# Altium schematic sheet Detection_Circuit_Sheet_02.SchDoc
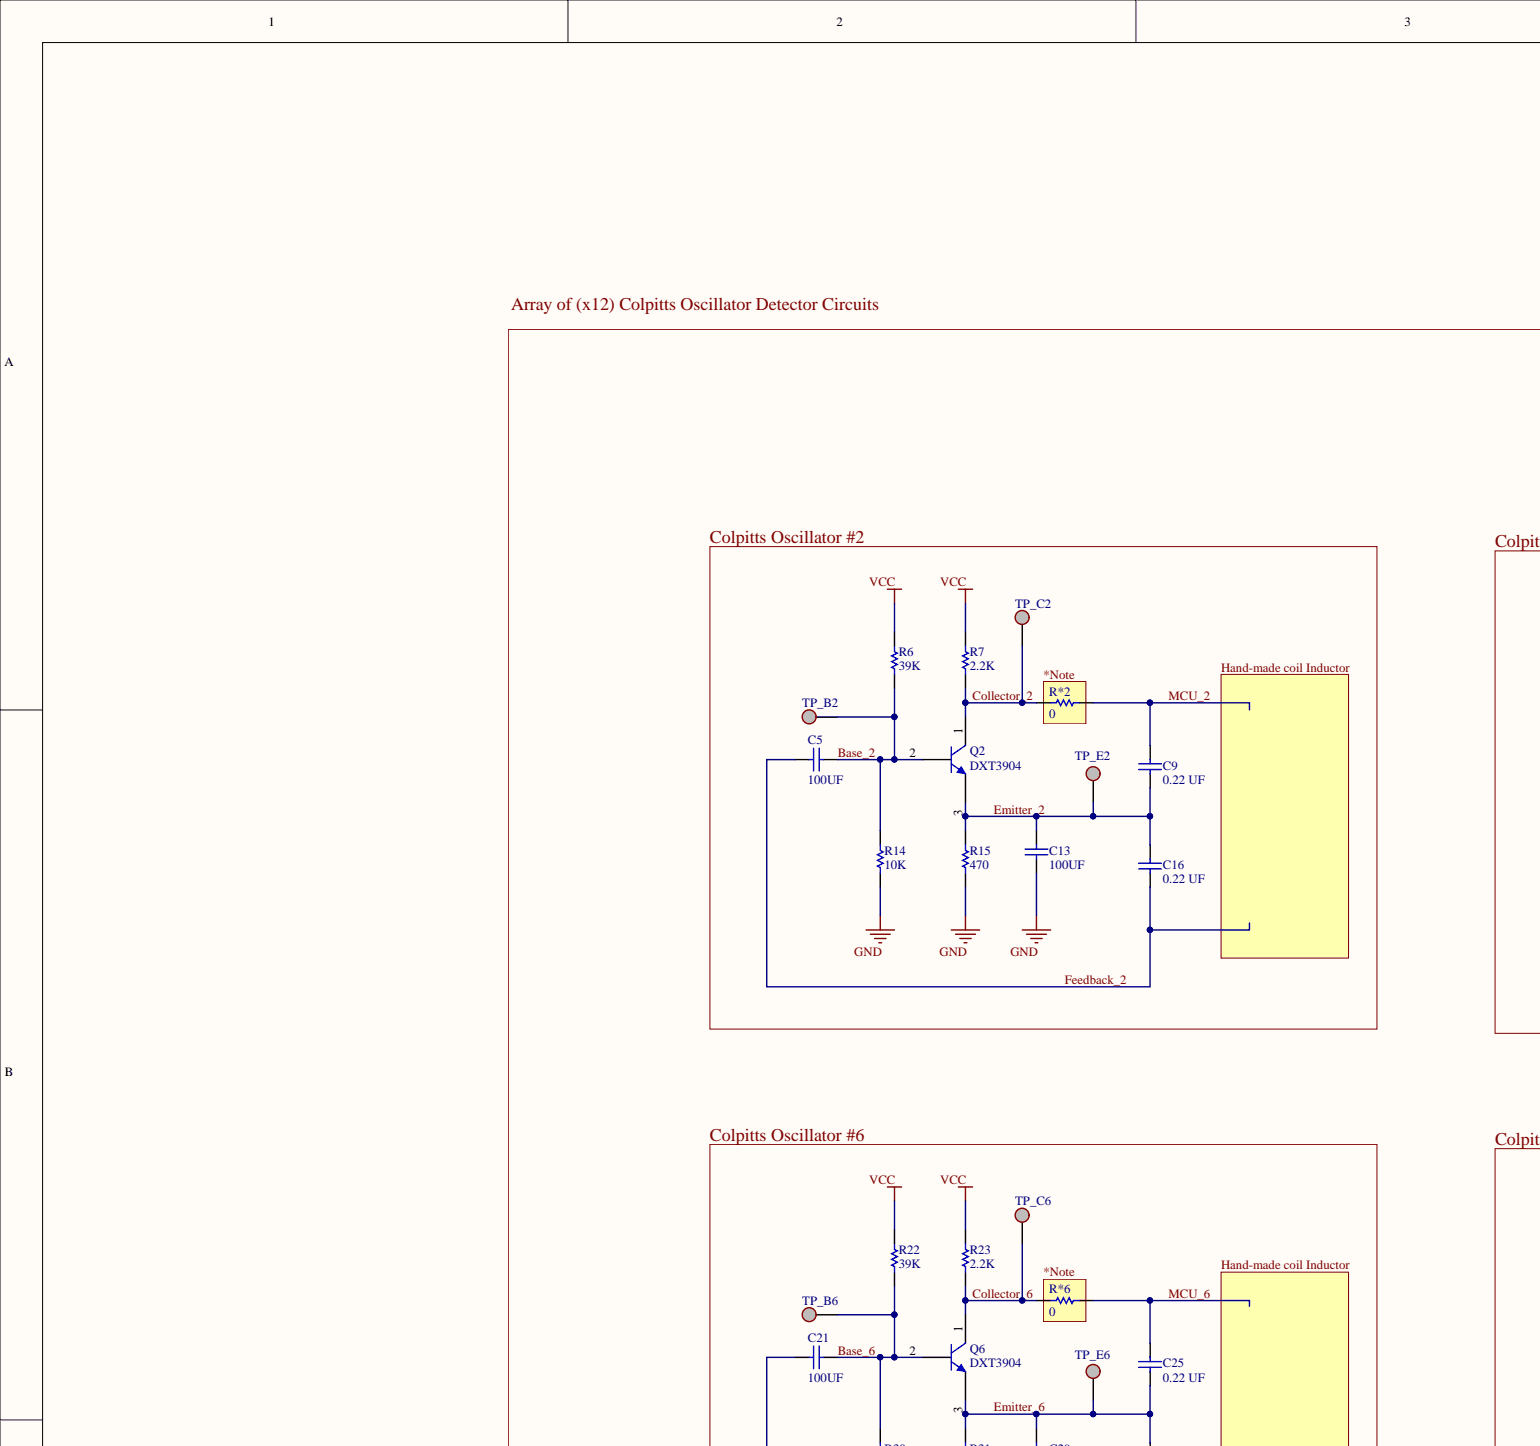
[diagram p0: Detection_Circuit_Sheet_02.SchDoc, top left]
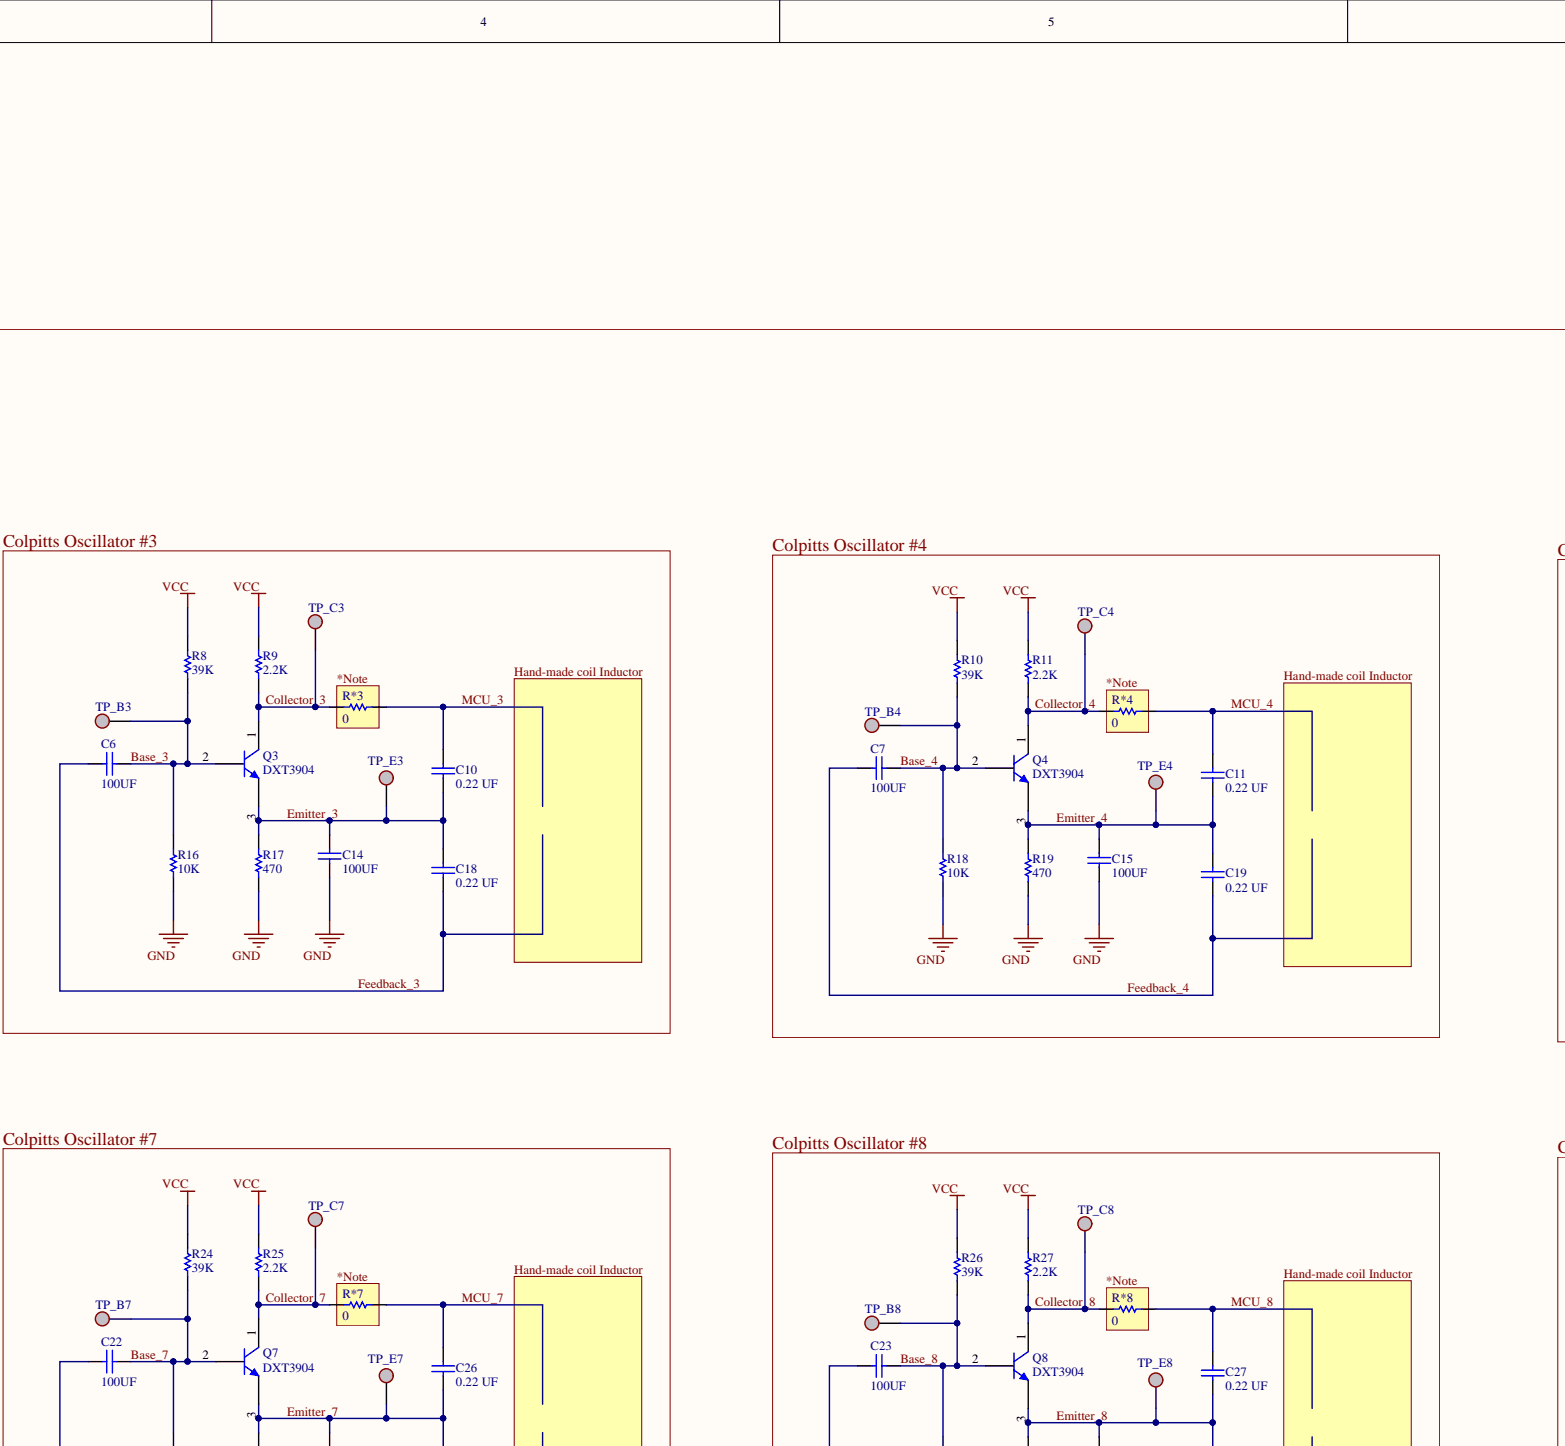
[diagram p1: Detection_Circuit_Sheet_02.SchDoc, top centre]
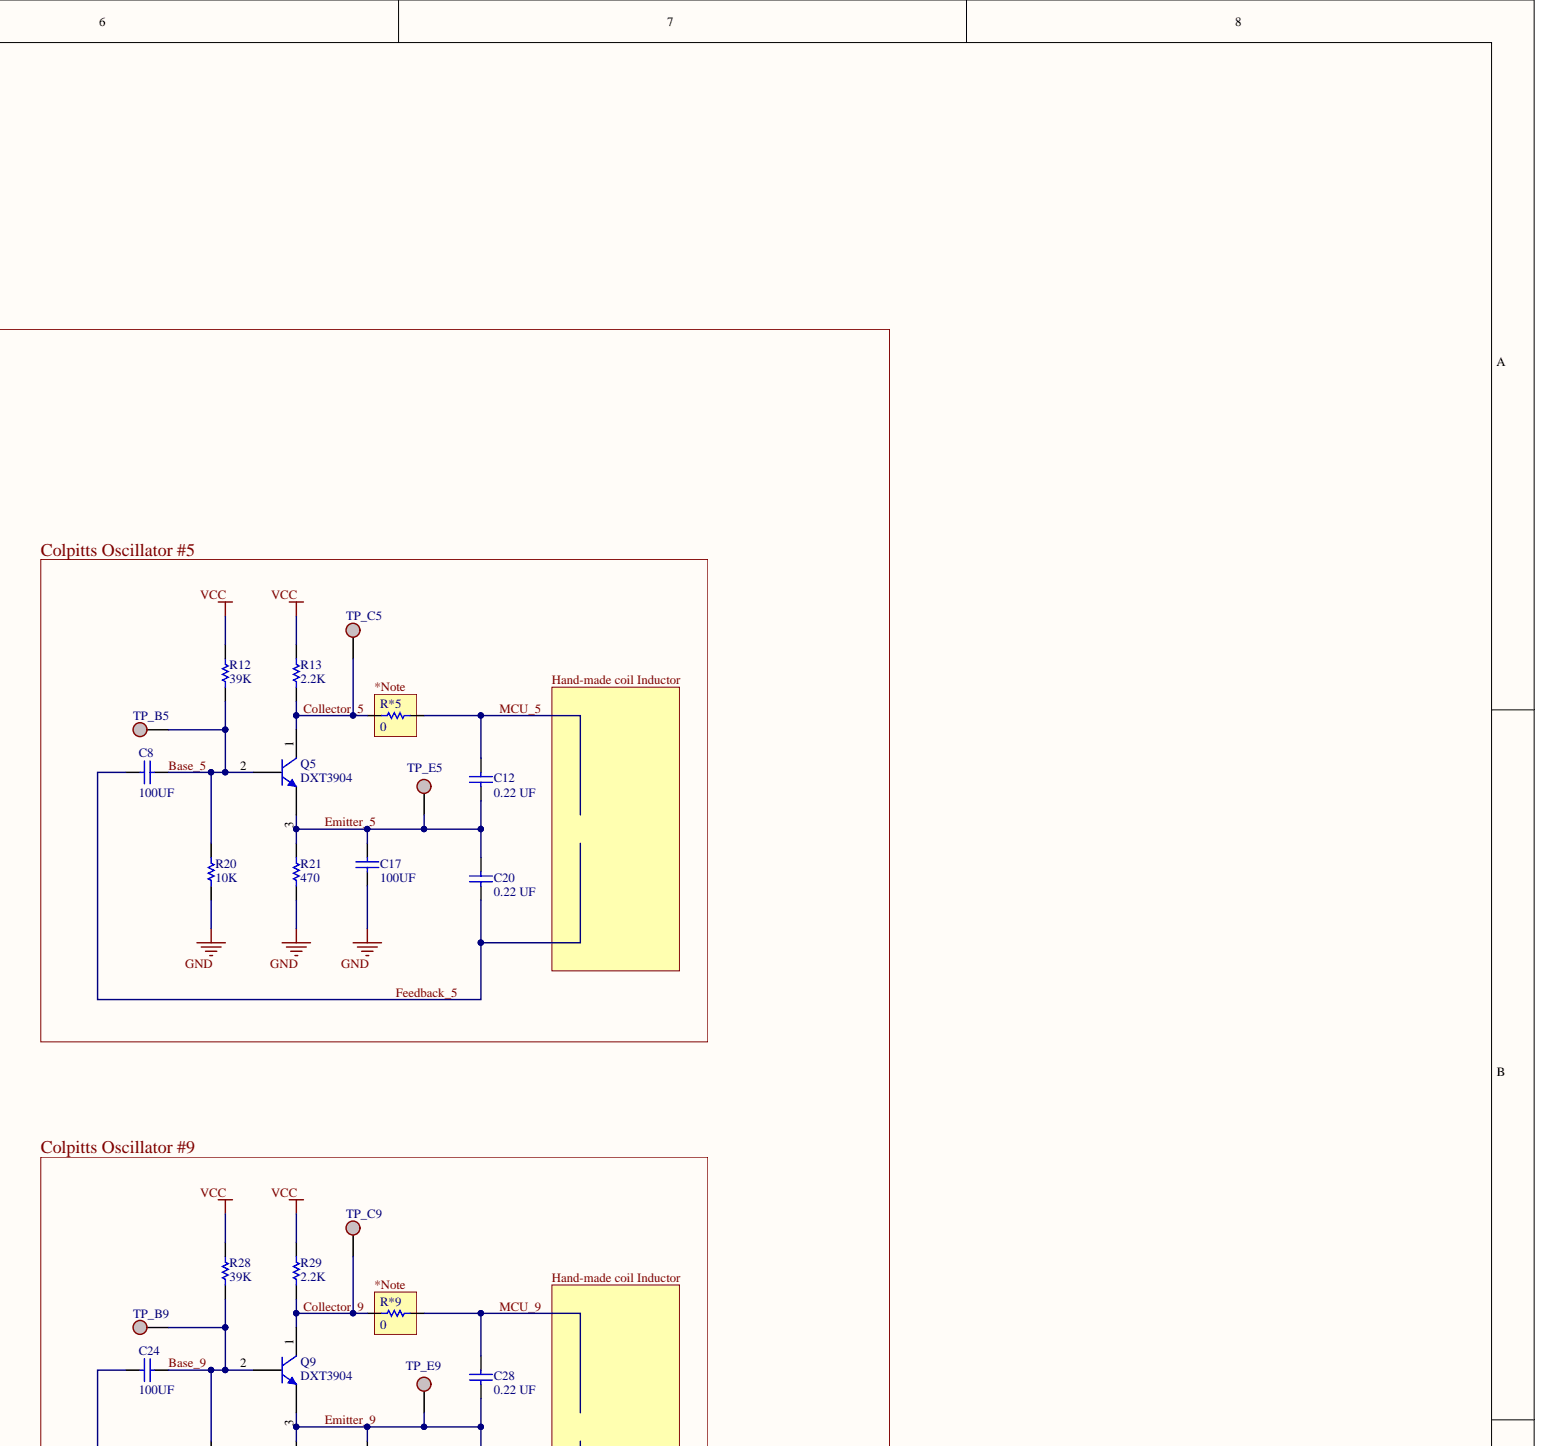
[diagram p2: Detection_Circuit_Sheet_02.SchDoc, top right]
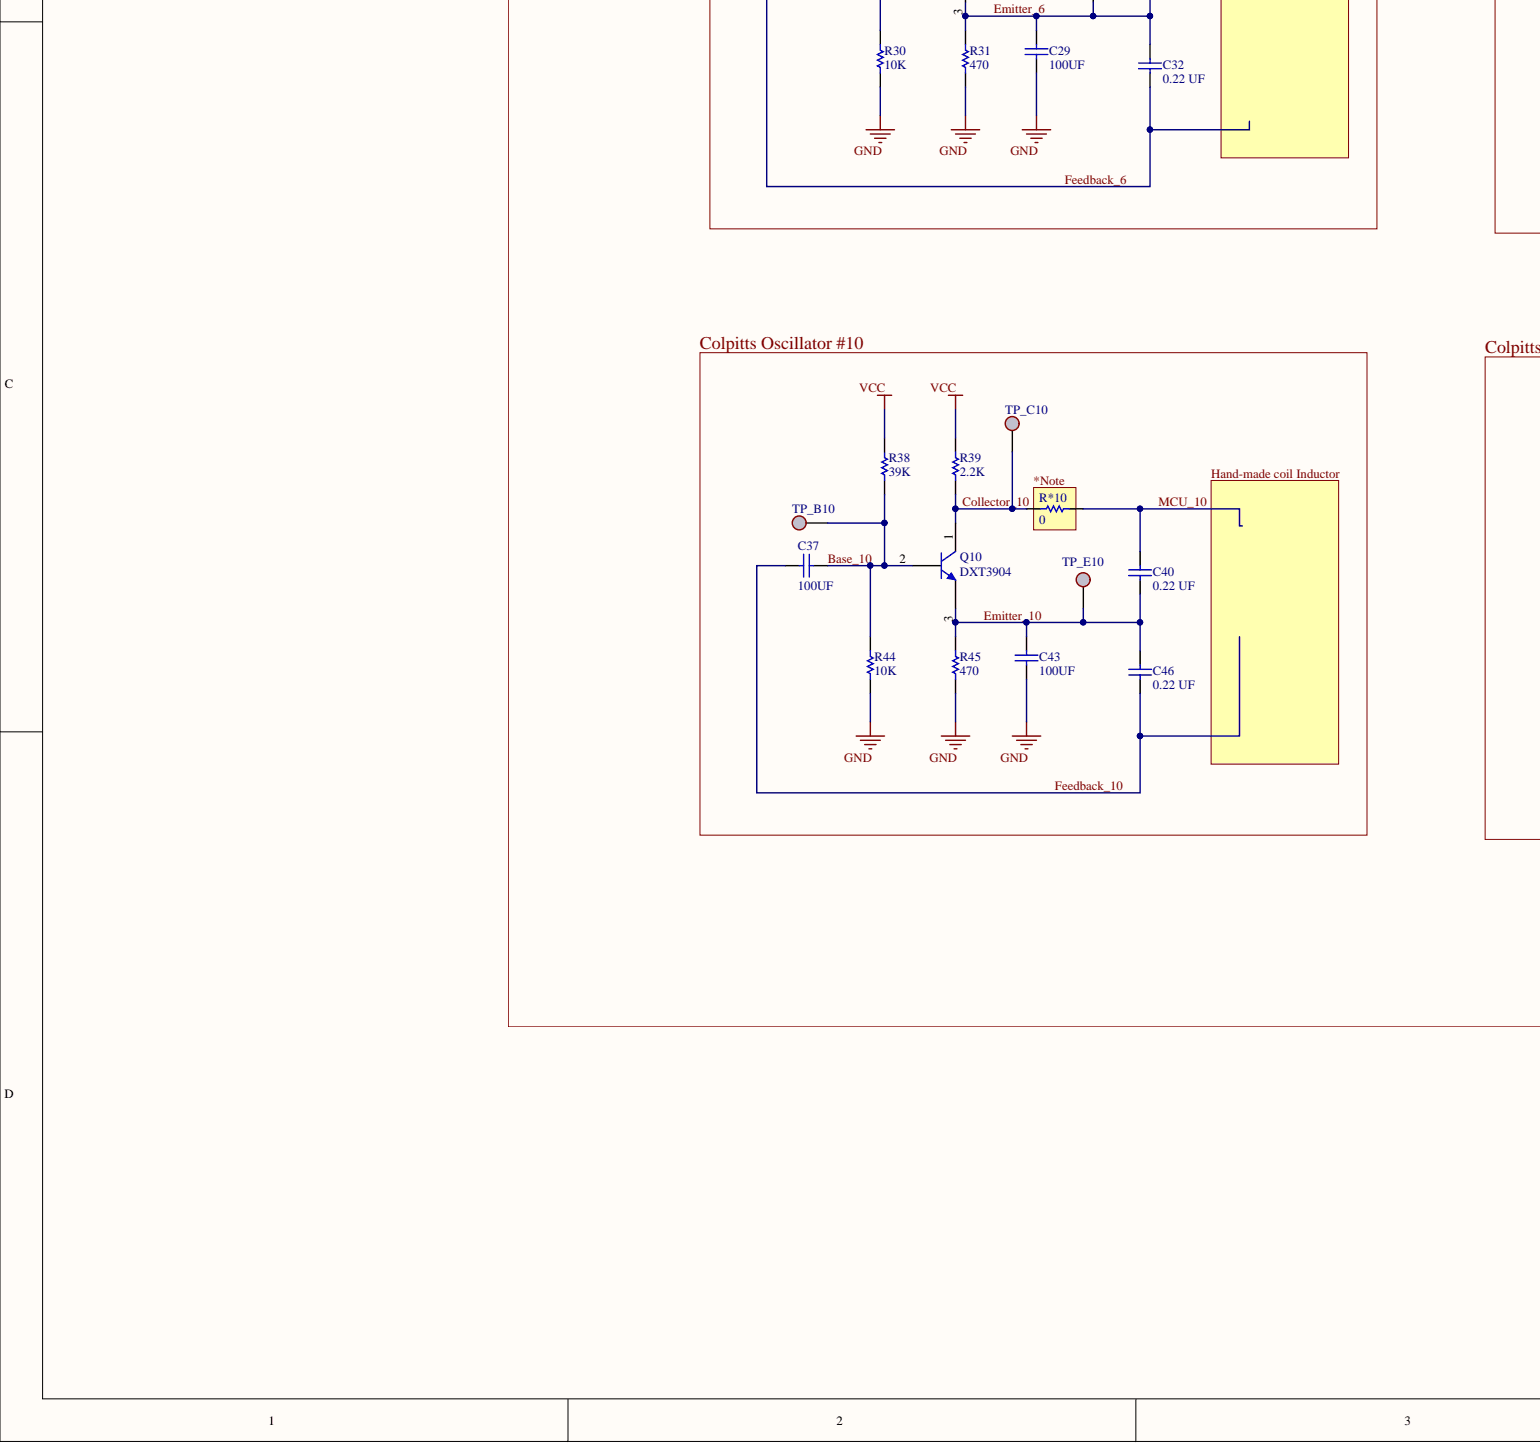
[diagram p3: Detection_Circuit_Sheet_02.SchDoc, bottom left]
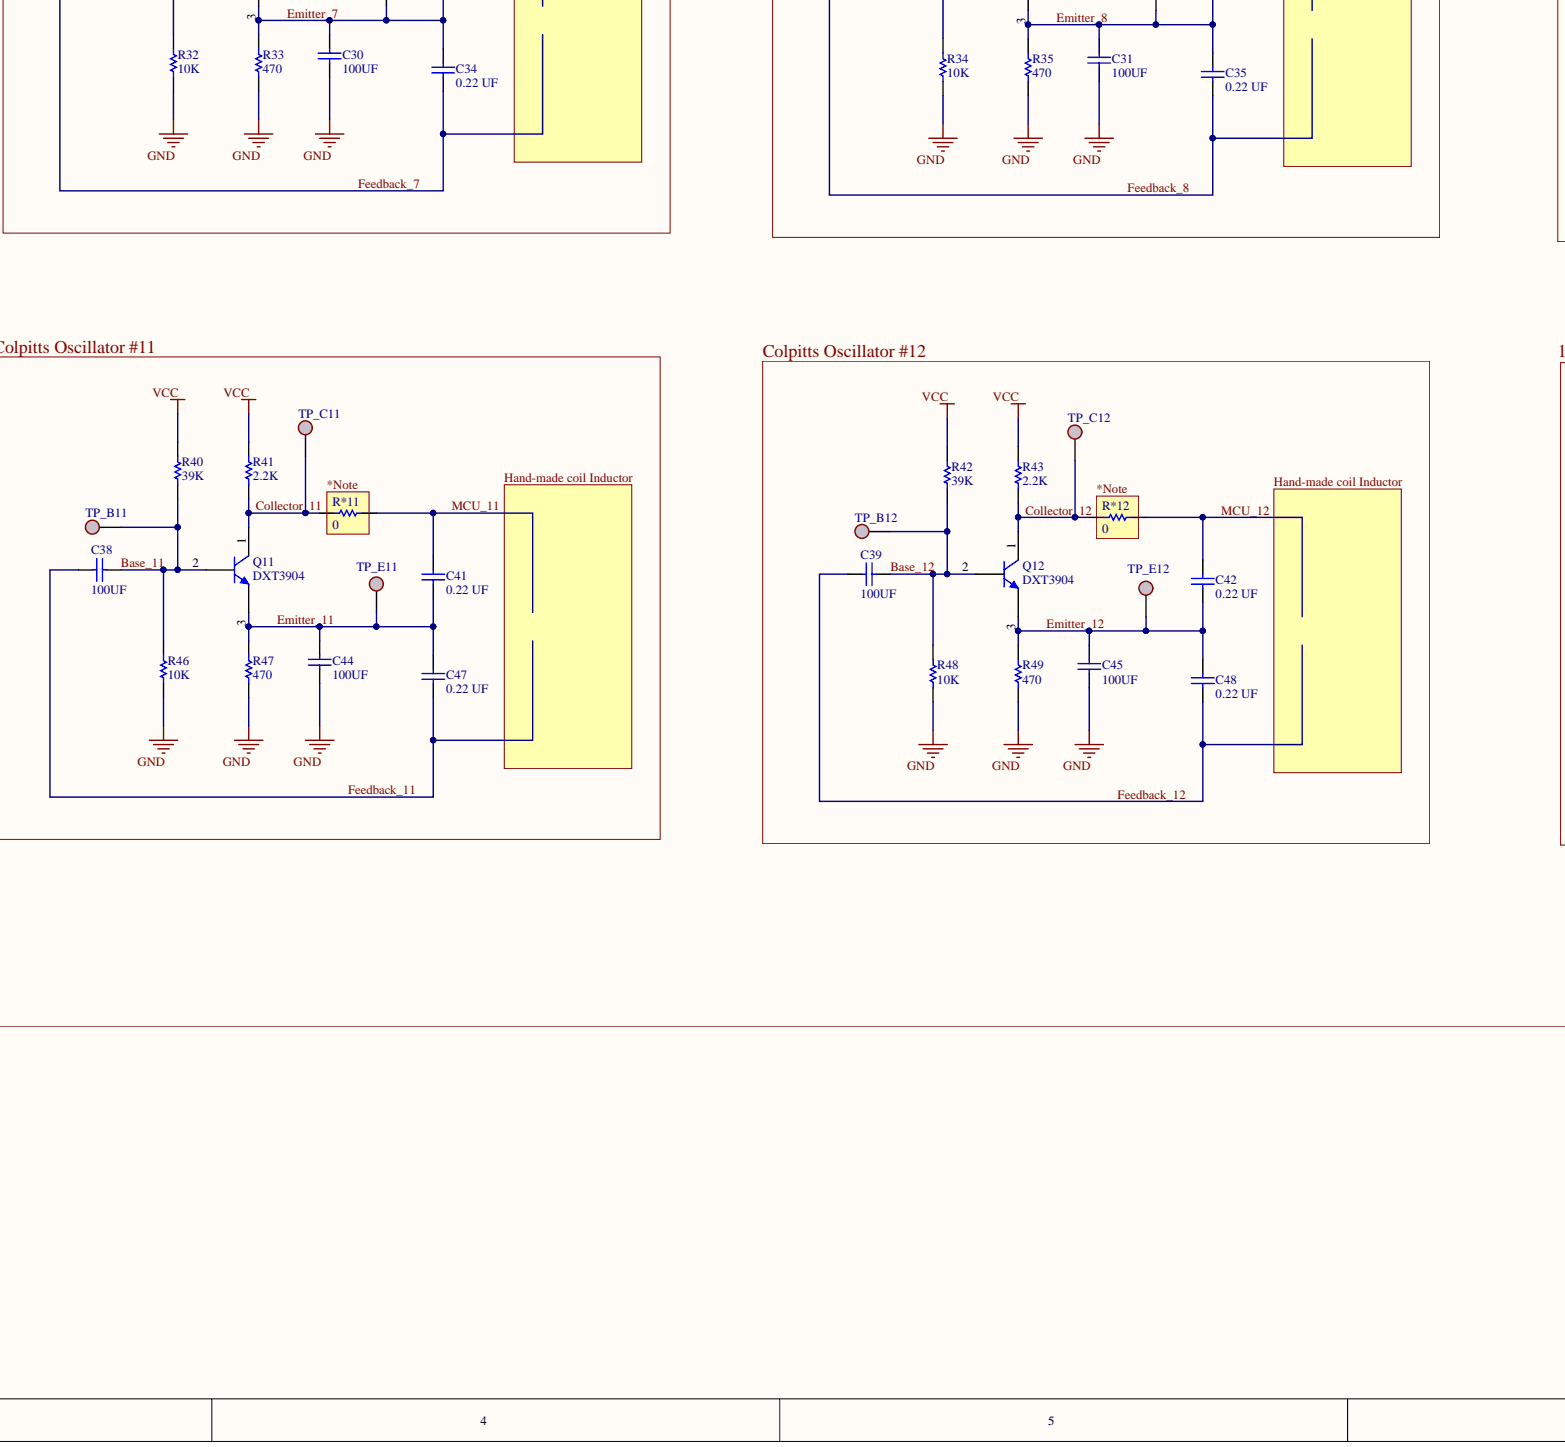
[diagram p4: Detection_Circuit_Sheet_02.SchDoc, bottom centre]
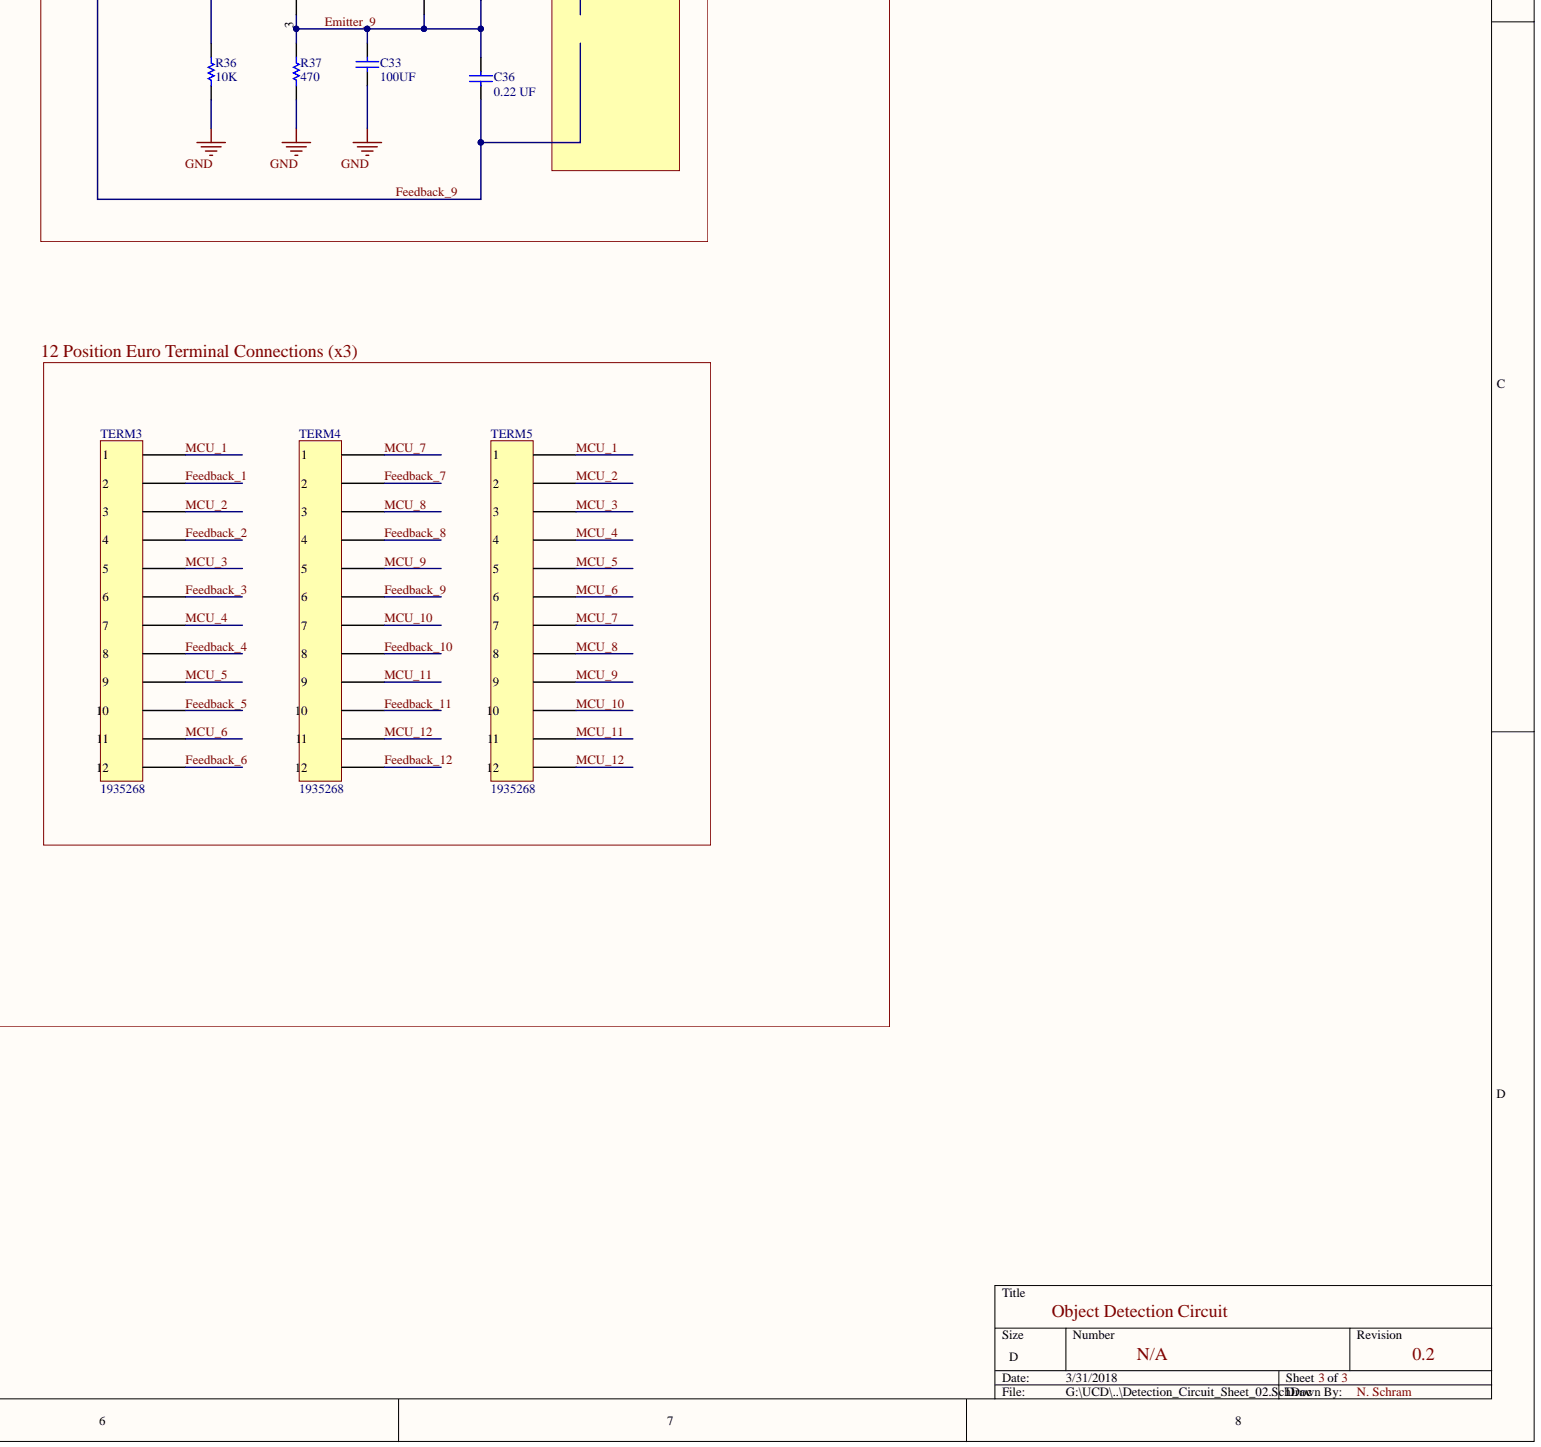
[diagram p5: Detection_Circuit_Sheet_02.SchDoc, bottom right]

## Parts
C5  100UF  [C1210_M]
C6  100UF  [C1210_M]
C7  100UF  [C1210_M]
C8  100UF  [C1210_M]
C9  Capacitance: 0.22 UF  [6-0805_N]  (hidden comment: 08055C224JAT2A)
C10  Capacitance: 0.22 UF  [6-0805_N]  (hidden comment: 08055C224JAT2A)
C11  Capacitance: 0.22 UF  [6-0805_N]  (hidden comment: 08055C224JAT2A)
C12  Capacitance: 0.22 UF  [6-0805_N]  (hidden comment: 08055C224JAT2A)
C13  100UF  [C1210_M]
C14  100UF  [C1210_M]
C15  100UF  [C1210_M]
C16  Capacitance: 0.22 UF  [6-0805_N]  (hidden comment: 08055C224JAT2A)
C17  100UF  [C1210_M]
C18  Capacitance: 0.22 UF  [6-0805_N]  (hidden comment: 08055C224JAT2A)
C19  Capacitance: 0.22 UF  [6-0805_N]  (hidden comment: 08055C224JAT2A)
C20  Capacitance: 0.22 UF  [6-0805_N]  (hidden comment: 08055C224JAT2A)
C21  100UF  [C1210_M]
C22  100UF  [C1210_M]
C23  100UF  [C1210_M]
C24  100UF  [C1210_M]
C25  Capacitance: 0.22 UF  [6-0805_N]  (hidden comment: 08055C224JAT2A)
C26  Capacitance: 0.22 UF  [6-0805_N]  (hidden comment: 08055C224JAT2A)
C27  Capacitance: 0.22 UF  [6-0805_N]  (hidden comment: 08055C224JAT2A)
C28  Capacitance: 0.22 UF  [6-0805_N]  (hidden comment: 08055C224JAT2A)
C29  100UF  [C1210_M]
C30  100UF  [C1210_M]
C31  100UF  [C1210_M]
C32  Capacitance: 0.22 UF  [6-0805_N]  (hidden comment: 08055C224JAT2A)
C33  100UF  [C1210_M]
C34  Capacitance: 0.22 UF  [6-0805_N]  (hidden comment: 08055C224JAT2A)
C35  Capacitance: 0.22 UF  [6-0805_N]  (hidden comment: 08055C224JAT2A)
C36  Capacitance: 0.22 UF  [6-0805_N]  (hidden comment: 08055C224JAT2A)
C37  100UF  [C1210_M]
C38  100UF  [C1210_M]
C39  100UF  [C1210_M]
C40  Capacitance: 0.22 UF  [6-0805_N]  (hidden comment: 08055C224JAT2A)
C41  Capacitance: 0.22 UF  [6-0805_N]  (hidden comment: 08055C224JAT2A)
C42  Capacitance: 0.22 UF  [6-0805_N]  (hidden comment: 08055C224JAT2A)
C43  100UF  [C1210_M]
C44  100UF  [C1210_M]
C45  100UF  [C1210_M]
C46  Capacitance: 0.22 UF  [6-0805_N]  (hidden comment: 08055C224JAT2A)
C47  Capacitance: 0.22 UF  [6-0805_N]  (hidden comment: 08055C224JAT2A)
C48  Capacitance: 0.22 UF  [6-0805_N]  (hidden comment: 08055C224JAT2A)
Q2  DXT3904  [DXT3904-13]
Q3  DXT3904  [DXT3904-13]
Q4  DXT3904  [DXT3904-13]
Q5  DXT3904  [DXT3904-13]
Q6  DXT3904  [DXT3904-13]
Q7  DXT3904  [DXT3904-13]
Q8  DXT3904  [DXT3904-13]
Q9  DXT3904  [DXT3904-13]
Q10  DXT3904  [DXT3904-13]
Q11  DXT3904  [DXT3904-13]
Q12  DXT3904  [DXT3904-13]
R6  39K  [6-0805_M]
R7  2.2K  [6-0805_M]
R8  39K  [6-0805_M]
R9  2.2K  [6-0805_M]
R10  39K  [6-0805_M]
R11  2.2K  [6-0805_M]
R12  39K  [6-0805_M]
R13  2.2K  [6-0805_M]
R14  10K  [6-0805_M]
R15  470  [6-0805_M]
R16  10K  [6-0805_M]
R17  470  [6-0805_M]
R18  10K  [6-0805_M]
R19  470  [6-0805_M]
R20  10K  [6-0805_M]
R21  470  [6-0805_M]
R22  39K  [6-0805_M]
R23  2.2K  [6-0805_M]
R24  39K  [6-0805_M]
R25  2.2K  [6-0805_M]
R26  39K  [6-0805_M]
R27  2.2K  [6-0805_M]
R28  39K  [6-0805_M]
R29  2.2K  [6-0805_M]
R30  10K  [6-0805_M]
R31  470  [6-0805_M]
R32  10K  [6-0805_M]
R33  470  [6-0805_M]
R34  10K  [6-0805_M]
R35  470  [6-0805_M]
R36  10K  [6-0805_M]
R37  470  [6-0805_M]
R38  39K  [6-0805_M]
R39  2.2K  [6-0805_M]
R40  39K  [6-0805_M]
R41  2.2K  [6-0805_M]
R42  39K  [6-0805_M]
R43  2.2K  [6-0805_M]
R44  10K  [6-0805_M]
R45  470  [6-0805_M]
R46  10K  [6-0805_M]
R47  470  [6-0805_M]
R48  10K  [6-0805_M]
R49  470  [6-0805_M]
R*2  0  [6-0805_M]
R*3  0  [6-0805_M]
R*4  0  [6-0805_M]
R*5  0  [6-0805_M]
R*6  0  [6-0805_M]
R*7  0  [6-0805_M]
R*8  0  [6-0805_M]
R*9  0  [6-0805_M]
R*10  0  [6-0805_M]
R*11  0  [6-0805_M]
R*12  0  [6-0805_M]
TERM3  1935268  [1935268]
TERM4  1935268  [1935268]
TERM5  1935268  [1935268]
TP_B2  TestPoint  [TestPoint]  (value not drawn)
TP_B3  TestPoint  [TestPoint]  (value not drawn)
TP_B4  TestPoint  [TestPoint]  (value not drawn)
TP_B5  TestPoint  [TestPoint]  (value not drawn)
TP_B6  TestPoint  [TestPoint]  (value not drawn)
TP_B7  TestPoint  [TestPoint]  (value not drawn)
TP_B8  TestPoint  [TestPoint]  (value not drawn)
TP_B9  TestPoint  [TestPoint]  (value not drawn)
TP_B10  TestPoint  [TestPoint]  (value not drawn)
TP_B11  TestPoint  [TestPoint]  (value not drawn)
TP_B12  TestPoint  [TestPoint]  (value not drawn)
TP_C2  TestPoint  [TestPoint]  (value not drawn)
TP_C3  TestPoint  [TestPoint]  (value not drawn)
TP_C4  TestPoint  [TestPoint]  (value not drawn)
TP_C5  TestPoint  [TestPoint]  (value not drawn)
TP_C6  TestPoint  [TestPoint]  (value not drawn)
TP_C7  TestPoint  [TestPoint]  (value not drawn)
TP_C8  TestPoint  [TestPoint]  (value not drawn)
TP_C9  TestPoint  [TestPoint]  (value not drawn)
TP_C10  TestPoint  [TestPoint]  (value not drawn)
TP_C11  TestPoint  [TestPoint]  (value not drawn)
TP_C12  TestPoint  [TestPoint]  (value not drawn)
TP_E2  TestPoint  [TestPoint]  (value not drawn)
TP_E3  TestPoint  [TestPoint]  (value not drawn)
TP_E4  TestPoint  [TestPoint]  (value not drawn)
TP_E5  TestPoint  [TestPoint]  (value not drawn)
TP_E6  TestPoint  [TestPoint]  (value not drawn)
TP_E7  TestPoint  [TestPoint]  (value not drawn)
TP_E8  TestPoint  [TestPoint]  (value not drawn)
TP_E9  TestPoint  [TestPoint]  (value not drawn)
TP_E10  TestPoint  [TestPoint]  (value not drawn)
TP_E11  TestPoint  [TestPoint]  (value not drawn)
TP_E12  TestPoint  [TestPoint]  (value not drawn)

## Nets
GND: C13.1 C14.1 C15.1 C17.1 C29.1 C30.1 C31.1 C33.1 C43.1 C44.1 C45.1 R14.1 R15.1 R16.1 R17.1 R18.1 R19.1 R20.1 R21.1 R30.1 R31.1 R32.1 R33.1 R34.1 R35.1 R36.1 R37.1 R44.1 R45.1 R46.1 R47.1 R48.1 R49.1
VCC: R6.2 R7.2 R8.2 R9.2 R10.2 R11.2 R12.2 R13.2 R22.2 R23.2 R24.2 R25.2 R26.2 R27.2 R28.2 R29.2 R38.2 R39.2 R40.2 R41.2 R42.2 R43.2
BASE_2: C5.2 Q2.2 R6.1 R14.2 TP_B2.1
BASE_3: C6.2 Q3.2 R8.1 R16.2 TP_B3.1
BASE_4: C7.2 Q4.2 R10.1 R18.2 TP_B4.1
BASE_5: C8.2 Q5.2 R12.1 R20.2 TP_B5.1
BASE_6: C21.2 Q6.2 R22.1 R30.2 TP_B6.1
BASE_7: C22.2 Q7.2 R24.1 R32.2 TP_B7.1
BASE_8: C23.2 Q8.2 R26.1 R34.2 TP_B8.1
BASE_9: C24.2 Q9.2 R28.1 R36.2 TP_B9.1
BASE_10: C37.2 Q10.2 R38.1 R44.2 TP_B10.1
BASE_11: C38.2 Q11.2 R40.1 R46.2 TP_B11.1
BASE_12: C39.2 Q12.2 R42.1 R48.2 TP_B12.1
COLLECTOR_2: Q2.1 R7.1 R*2.1 TP_C2.1
COLLECTOR_3: Q3.1 R9.1 R*3.1 TP_C3.1
COLLECTOR_4: Q4.1 R11.1 R*4.1 TP_C4.1
COLLECTOR_5: Q5.1 R13.1 R*5.1 TP_C5.1
COLLECTOR_6: Q6.1 R23.1 R*6.1 TP_C6.1
COLLECTOR_7: Q7.1 R25.1 R*7.1 TP_C7.1
COLLECTOR_8: Q8.1 R27.1 R*8.1 TP_C8.1
COLLECTOR_9: Q9.1 R29.1 R*9.1 TP_C9.1
COLLECTOR_10: Q10.1 R39.1 R*10.1 TP_C10.1
COLLECTOR_11: Q11.1 R41.1 R*11.1 TP_C11.1
COLLECTOR_12: Q12.1 R43.1 R*12.1 TP_C12.1
EMITTER_2: C9.1 C13.2 C16.2 Q2.3 R15.2 TP_E2.1
EMITTER_3: C10.1 C14.2 C18.2 Q3.3 R17.2 TP_E3.1
EMITTER_4: C11.1 C15.2 C19.2 Q4.3 R19.2 TP_E4.1
EMITTER_5: C12.1 C17.2 C20.2 Q5.3 R21.2 TP_E5.1
EMITTER_6: C25.1 C29.2 C32.2 Q6.3 R31.2 TP_E6.1
EMITTER_7: C26.1 C30.2 C34.2 Q7.3 R33.2 TP_E7.1
EMITTER_8: C27.1 C31.2 C35.2 Q8.3 R35.2 TP_E8.1
EMITTER_9: C28.1 C33.2 C36.2 Q9.3 R37.2 TP_E9.1
EMITTER_10: C40.1 C43.2 C46.2 Q10.3 R45.2 TP_E10.1
EMITTER_11: C41.1 C44.2 C47.2 Q11.3 R47.2 TP_E11.1
EMITTER_12: C42.1 C45.2 C48.2 Q12.3 R49.2 TP_E12.1
FEEDBACK_1: TERM3.2
FEEDBACK_2: C5.1 C16.1 TERM3.4
FEEDBACK_3: C6.1 C18.1 TERM3.6
FEEDBACK_4: C7.1 C19.1 TERM3.8
FEEDBACK_5: C8.1 C20.1 TERM3.10
FEEDBACK_6: C21.1 C32.1 TERM3.12
FEEDBACK_7: C22.1 C34.1 TERM4.2
FEEDBACK_8: C23.1 C35.1 TERM4.4
FEEDBACK_9: C24.1 C36.1 TERM4.6
FEEDBACK_10: C37.1 C46.1 TERM4.8
FEEDBACK_11: C38.1 C47.1 TERM4.10
FEEDBACK_12: C39.1 C48.1 TERM4.12
MCU_1: TERM3.1 TERM5.1
MCU_2: C9.2 R*2.2 TERM3.3 TERM5.2
MCU_3: C10.2 R*3.2 TERM3.5 TERM5.3
MCU_4: C11.2 R*4.2 TERM3.7 TERM5.4
MCU_5: C12.2 R*5.2 TERM3.9 TERM5.5
MCU_6: C25.2 R*6.2 TERM3.11 TERM5.6
MCU_7: C26.2 R*7.2 TERM4.1 TERM5.7
MCU_8: C27.2 R*8.2 TERM4.3 TERM5.8
MCU_9: C28.2 R*9.2 TERM4.5 TERM5.9
MCU_10: C40.2 R*10.2 TERM4.7 TERM5.10
MCU_11: C41.2 R*11.2 TERM4.9 TERM5.11
MCU_12: C42.2 R*12.2 TERM4.11 TERM5.12

## Library data of the parts
C5-C8 C13-C15 C17 C21-C24 C29-C31 C33 C37-C39 C43-C45 = CC1210MKX5R7BB107: CAP CER 100UF 16V X5R 1210; Manufacturer: Yageo; Capacitance: 100µF; Tolerance: ±20%; Applications: General Purpose; Category: Capacitors; Component Kind: Standard; ComponentLink1Description: http://www.mouser.com/ds/2/447/Yageo_UPY-GP_NP0_16V-to-50V_9-358835.pdf; ComponentLink1URL: http://www.mouser.com/ds/2/447/Yageo_UPY-GP_NP0_16V-to-50V_9-358835.pdf; ComponentLink2Description: http://www.yageo.com/documents/recent/UPY-GPHC_X5R_4V-to-50V_25.pdf; ComponentLink2URL: http://www.yageo.com/documents/recent/UPY-GPHC_X5R_4V-to-50V_25.pdf; Family: Ceramic Capacitors; Features: -; Height - Seated (Max): -; Lead Spacing: -; Lead Style: -; Library Name: CC1210MKX5R7BB107.SchLib; Manufacturer Part Number: CC1210MKX5R7BB107; Mounting Type: Surface Mount, MLCC; Operating Temperature: -55°C ~ 85°C; Package / Case: 1210 (3225 Metric); Packaging: Tape & Reel (TR); Pricing 1: 1=1.82, 10=1.437, 100=1.0778 (USD); Ratings: -; Series: CC; Size / Dimension: 0.126" L x 0.098" W (3.20mm x 2.50mm); Stock 1: 19901; Supplier 1: Digi-Key; Supplier Part Number 1: 311-2052-1-ND; Temperature Coefficient: X5R; Thickness (Max): 0.110" (2.80mm); Voltage - Rated: 16V
C9-C12 C16 C18-C20 C25-C28 C32 C34-C36 C40-C42 C46-C48 = 08055C224JAT2A: CAP CER 0.22UF 50V X7R 0805; Manufacturer: AVX Corporation; Notification: Current market demand for these product types have resulted in fluctuating and extending lead times. Lead times may differ.; Tolerance: ±5%; Applications: General Purpose; Category: Capacitors; Component Kind: Standard; ComponentLink1Description: http://datasheets.avx.com/X7RDielectric.pdf; ComponentLink1URL: http://datasheets.avx.com/X7RDielectric.pdf; Features: -; Height - Seated (Max): -; Lead Spacing: -; Lead Style: -; Library Name: 08055C224JAT2A.SchLib; Manufacturer Part Number: 08055C224JAT2A; Mounting Type: Surface Mount, MLCC; Operating Temperature: -55°C ~ 125°C; Package / Case: 0805 (2012 Metric); Packaging: Cut Tape (CT); Part Status: Active; Pricing 1: 1=0.55, 10=0.387, 100=0.255, 500=0.18886, 1000=0.16053 (USD); Ratings: -; RoHS: RoHS Compliant; Size / Dimension: 0.079" L x 0.049" W (2.00mm x 1.25mm); Stock 1: 25172; Supplier 1: Digi-Key; Supplier Part Number 1: 478-7437-1-ND; Temperature Coefficient: X7R; Thickness (Max): 0.037" (0.94mm); Voltage - Rated: 50V
Q2-Q12 = DXT3904-13: TRANS NPN 40V 0.2A SOT89-3; Manufacturer: Diodes; Brand: Diodes Incorporated; Collector- Base Voltage VCBO: 60 V; Collector-Emitter Saturation Voltage: 300 mV; Configuration: Single; DC Collector/Base Gain hfe Min: 30 at 100 mA, 1 V; Emitter- Base Voltage VEBO: 6 V; Gain Bandwidth Product fT: 300 MHz; Maximum DC Collector Current: 200 mA; Pd - Power Dissipation: 1000 mW; Unit Weight: 0.001834 oz; Collector- Emitter Voltage VCEO Max: 40 V; Component Kind: Standard; ComponentLink1Description: https://datasheet.ciiva.com/979/ds31141-979988.pdf; ComponentLink1URL: https://datasheet.ciiva.com/979/ds31141-979988.pdf; ComponentLink2Description: https://datasheet.ciiva.com/4724/ds31141-4724919.pdf; ComponentLink2URL: https://datasheet.ciiva.com/4724/ds31141-4724919.pdf; ComponentLink3Description: https://datasheet.ciiva.com/17303/ds31141-17303788.pdf; ComponentLink3URL: https://datasheet.ciiva.com/17303/ds31141-17303788.pdf; ComponentLink4Description: https://datasheet.ciiva.com/11206/ds31141-11206239.pdf; ComponentLink4URL: https://datasheet.ciiva.com/11206/ds31141-11206239.pdf; Factory Pack Quantity: 2500; Height: 1.5 mm; Length: 4.5 mm; Library Name: DXT3904-13.SchLib; Manufacturer Part Number: DXT3904-13; Maximum Operating Temperature: + 150 C; Minimum Operating Temperature: - 55 C; Mounting Style: SMD/SMT; Package / Case: SOT-89; Packaging: Reel; Pricing 1: 1=0.53, 10=0.426, 100=0.29, 500=0.2175, 1000=0.1632 (USD); Product Category: Bipolar Transistors - BJT; RoHS: True; Series: DXT3904; Stock 1: 10942; Supplier 1: Digi-Key; Supplier Part Number 1: DXT3904-13DICT-ND; Transistor Polarity: NPN; Width: 2.48 mm
R6 R8 R10 R12 R22 R24 R26 R28 R38 R40 R42 = ERJ-PB6D3902V: RES SMD 39K OHM 0.5% 1/4W 0805; Manufacturer: Panasonic; Power (Watts): 0.25W, 1/4W; Temperature Coefficient: ±50ppm/°C; Category: Resistors; Component Kind: Standard; ComponentLink1Description: https://industrial.panasonic.com/sa/system/files/data/download/catalog/id_fixed-resistors_e_2.pdf; ComponentLink1URL: https://industrial.panasonic.com/sa/system/files/data/download/catalog/id_fixed-resistors_e_2.pdf; Composition: Thick Film; Failure Rate: -; Family: Chip Resistor - Surface Mount; Features: Automotive AEC-Q200, Pulse Withstanding; Height - Seated (Max): 0.028" (0.70mm); Library Name: ERJ-PB6D3902V.SchLib; Manufacturer Part Number: ERJ-PB6D3902V; Number of Terminations: 2; Operating Temperature: -55°C ~ 155°C; Package / Case: 0805 (2012 Metric); Packaging: Tape & Reel (TR); Part Status: Active; Pricing 1: 1=0.24, 10=0.204, 25=0.1488, 50=0.1078, 100=0.0799, 250=0.0614, 500=0.0483, 1000=0.0335 (USD); Resistance (Ohms): 39k; Series: ERJ; Size / Dimension: 0.079" L x 0.049" W (2.00mm x 1.25mm); Stock 1: 2236; Supplier 1: Digi-Key; Supplier Device Package: 0805; Supplier Part Number 1: P21199CT-ND; Tolerance: ±0.5%
R7 R9 R11 R13 R23 R25 R27 R29 R39 R41 R43 = ERJ-PB6D2201V: RES SMD 2.2K OHM 0.5% 1/4W 0805; Manufacturer: Panasonic; Power (Watts): 0.25W, 1/4W; Temperature Coefficient: ±50ppm/°C; Category: Resistors; Component Kind: Standard; ComponentLink1Description: https://industrial.panasonic.com/sa/system/files/data/download/catalog/id_fixed-resistors_e_2.pdf; ComponentLink1URL: https://industrial.panasonic.com/sa/system/files/data/download/catalog/id_fixed-resistors_e_2.pdf; Composition: Thick Film; Failure Rate: -; Family: Chip Resistor - Surface Mount; Features: Automotive AEC-Q200, Pulse Withstanding; Height - Seated (Max): 0.028" (0.70mm); Library Name: ERJ-PB6D2201V.SchLib; Manufacturer Part Number: ERJ-PB6D2201V; Number of Terminations: 2; Operating Temperature: -55°C ~ 155°C; Package / Case: 0805 (2012 Metric); Packaging: Tape & Reel (TR); Part Status: Active; Pricing 1: 1=0.24, 10=0.204, 25=0.1488, 50=0.1078, 100=0.0799, 250=0.0614, 500=0.0483, 1000=0.0335 (USD); Resistance (Ohms): 2.2k; Series: ERJ; Size / Dimension: 0.079" L x 0.049" W (2.00mm x 1.25mm); Stock 1: 3147; Supplier 1: Digi-Key; Supplier Device Package: 0805; Supplier Part Number 1: P21055CT-ND; Tolerance: ±0.5%
R14 R16 R18 R20 R30 R32 R34 R36 R44 R46 R48 = RNCP0805FTD10K0: RES SMD 10K OHM 1% 1/4W 0805; Manufacturer: Stackpole Electronics; Power (Watts): 0.25W, 1/4W; Temperature Coefficient: ±100ppm/°C; Category: Resistors; Component Kind: Standard; ComponentLink1Description: http://www.seielect.com/Catalog/SEI-rncp.pdf; ComponentLink1URL: http://www.seielect.com/Catalog/SEI-rncp.pdf; Composition: Thin Film; Family: Chip Resistor - Surface Mount; Features: Anti-Sulfur, Moisture Resistant; Height: 0.024" (0.60mm); Library Name: RNCP0805FTD10K0.SchLib; Manufacturer Part Number: RNCP0805FTD10K0; Number of Terminations: 2; Operating Temperature: -55°C ~ 155°C; Package / Case: 0805 (2012 Metric); Packaging: Tape & Reel (TR); Pricing 1: 1=0.1, 10=0.037, 100=0.015, 1000=0.0067, 2500=0.0058 (USD); Resistance (Ohms): 10k; Series: RNCP; Size / Dimension: 0.079" L x 0.049" W (2.00mm x 1.25mm); Stock 1: 103823; Supplier 1: Digi-Key; Supplier Device Package: 0805; Supplier Part Number 1: RNCP0805FTD10K0CT-ND; Tolerance: ±1%
R15 R17 R19 R21 R31 R33 R35 R37 R45 R47 R49 = ERJ-PB6D4700V: RES SMD 470 OHM 0.5% 1/4W 0805; Manufacturer: Panasonic; Power (Watts): 0.25W, 1/4W; Temperature Coefficient: ±50ppm/°C; Category: Resistors; Component Kind: Standard; ComponentLink1Description: https://industrial.panasonic.com/sa/system/files/data/download/catalog/id_fixed-resistors_e_2.pdf; ComponentLink1URL: https://industrial.panasonic.com/sa/system/files/data/download/catalog/id_fixed-resistors_e_2.pdf; Composition: Thick Film; Failure Rate: -; Family: Chip Resistor - Surface Mount; Features: Automotive AEC-Q200, Pulse Withstanding; Height - Seated (Max): 0.028" (0.70mm); Library Name: ERJ-PB6D4700V.SchLib; Manufacturer Part Number: ERJ-PB6D4700V; Number of Terminations: 2; Operating Temperature: -55°C ~ 155°C; Package / Case: 0805 (2012 Metric); Packaging: Cut Tape (CT); Part Status: Active; Pricing 1: 1=0.24, 10=0.204, 25=0.1488, 50=0.1078, 100=0.0799, 250=0.0614, 500=0.0483, 1000=0.0335 (USD); Resistance (Ohms): 470; Series: ERJ; Size / Dimension: 0.079" L x 0.049" W (2.00mm x 1.25mm); Stock 1: 2688; Supplier 1: Digi-Key; Supplier Device Package: 0805; Supplier Part Number 1: P20981CT-ND; Tolerance: ±0.5%
R*2-R*12 = ERJ-6GEY0R00V: RES SMD 0 OHM JUMPER 1/8W 0805; Manufacturer: Panasonic ECG; Rated Power: 0.125 W; Resistance: 0 O; Temp Coefficient: 0 ppm/°C; Tolerance (%): 0 %; Component Kind: Standard; ComponentLink1Description: https://datasheet.ciiva.com/28695/1678796-28695877.pdf; ComponentLink1URL: https://datasheet.ciiva.com/28695/1678796-28695877.pdf; ComponentLink2Description: https://datasheet.ciiva.com/11821/0900766b80f806dd-11821735.pdf; ComponentLink2URL: https://datasheet.ciiva.com/11821/0900766b80f806dd-11821735.pdf; ComponentLink3Description: https://datasheet.ciiva.com/43460/1678796-43460073.pdf; ComponentLink3URL: https://datasheet.ciiva.com/43460/1678796-43460073.pdf; ComponentLink4Description: https://datasheet.ciiva.com/9117/aoa0000ce1-9117354.pdf; ComponentLink4URL: https://datasheet.ciiva.com/9117/aoa0000ce1-9117354.pdf; ComponentLink5Description: https://datasheet.ciiva.com/46377/1678796-46377866.pdf; ComponentLink5URL: https://datasheet.ciiva.com/46377/1678796-46377866.pdf; ComponentLink6Description: https://datasheet.ciiva.com/36526/1989607-36526237.pdf; ComponentLink6URL: https://datasheet.ciiva.com/36526/1989607-36526237.pdf; ComponentLink7Description: https://datasheet.ciiva.com/17215/aoa0000ce1-17215390.pdf; ComponentLink7URL: https://datasheet.ciiva.com/17215/aoa0000ce1-17215390.pdf; ComponentLink8Description: https://datasheet.ciiva.com/16512/aoa0000ce1-16512111.pdf; ComponentLink8URL: https://datasheet.ciiva.com/16512/aoa0000ce1-16512111.pdf; Library Name: ERJ-6GEY0R00V.SchLib; Manufacturer Part Number: ERJ-6GEY0R00V; Pricing 1: 1=0.1, 10=0.053, 25=0.038, 50=0.029, 100=0.0214, 250=0.0163, 500=0.0131, 1000=0.0096 (USD); Size Code: 0805; Stock 1: 6840904; Supplier 1: Digi-Key; Supplier Part Number 1: P0.0ACT-ND
TERM3-TERM5 = 1935268: TERM BLOCK PCB 12POS 5.0MM GREEN; Manufacturer: Phoenix Contact; Mating Orientation: Horizontal with Board; Pitch: 0.197" (5.00mm); Positions Per Level: 12; Screw Thread: M2.6; Torque - Screw: 0.35-0.4 Nm (3.1-3.5 Lb-In); Wire Gauge: 12-26 AWG; Wire Termination: Screw; Category: Connectors, Interconnects; Clamp Material - Plating: -; Color: Green; Component Kind: Standard; ComponentLink1Description: https://media.digikey.com/pdf/Data%20Sheets/Phoenix%20Contact%20PDFs/1935268.pdf; ComponentLink1URL: https://media.digikey.com/pdf/Data%20Sheets/Phoenix%20Contact%20PDFs/1935268.pdf; Contact Material - Plating: -; Features: Interlocking (Side); Housing Material: Polyamide (PA), Nylon; Library Name: 1935268.SchLib; Manufacturer Part Number: 1935268; Mounting Type: Through Hole; Operating Temperature: -; Packaging: Bulk; Part Status: Active; Pricing 1: 1=2.2, 10=2.14, 50=2, 100=1.84, 250=1.7 (USD); RoHS: RoHS Compliant; Screw Material - Plating: -; Stock 1: 4448; Supplier 1: Digi-Key; Supplier Part Number 1: 277-1587-ND
TP_B2-TP_B12 TP_C2-TP_C12 TP_E2-TP_E12 = TestPoint: Test Point; Component Kind: Standard; Library Name: TestPoint.SchLib
